# Altium schematic sheet 419-005-01-02_PG 13 - Video_Out.SchDoc("419-005-01-02_PG 13 - Video_Out")
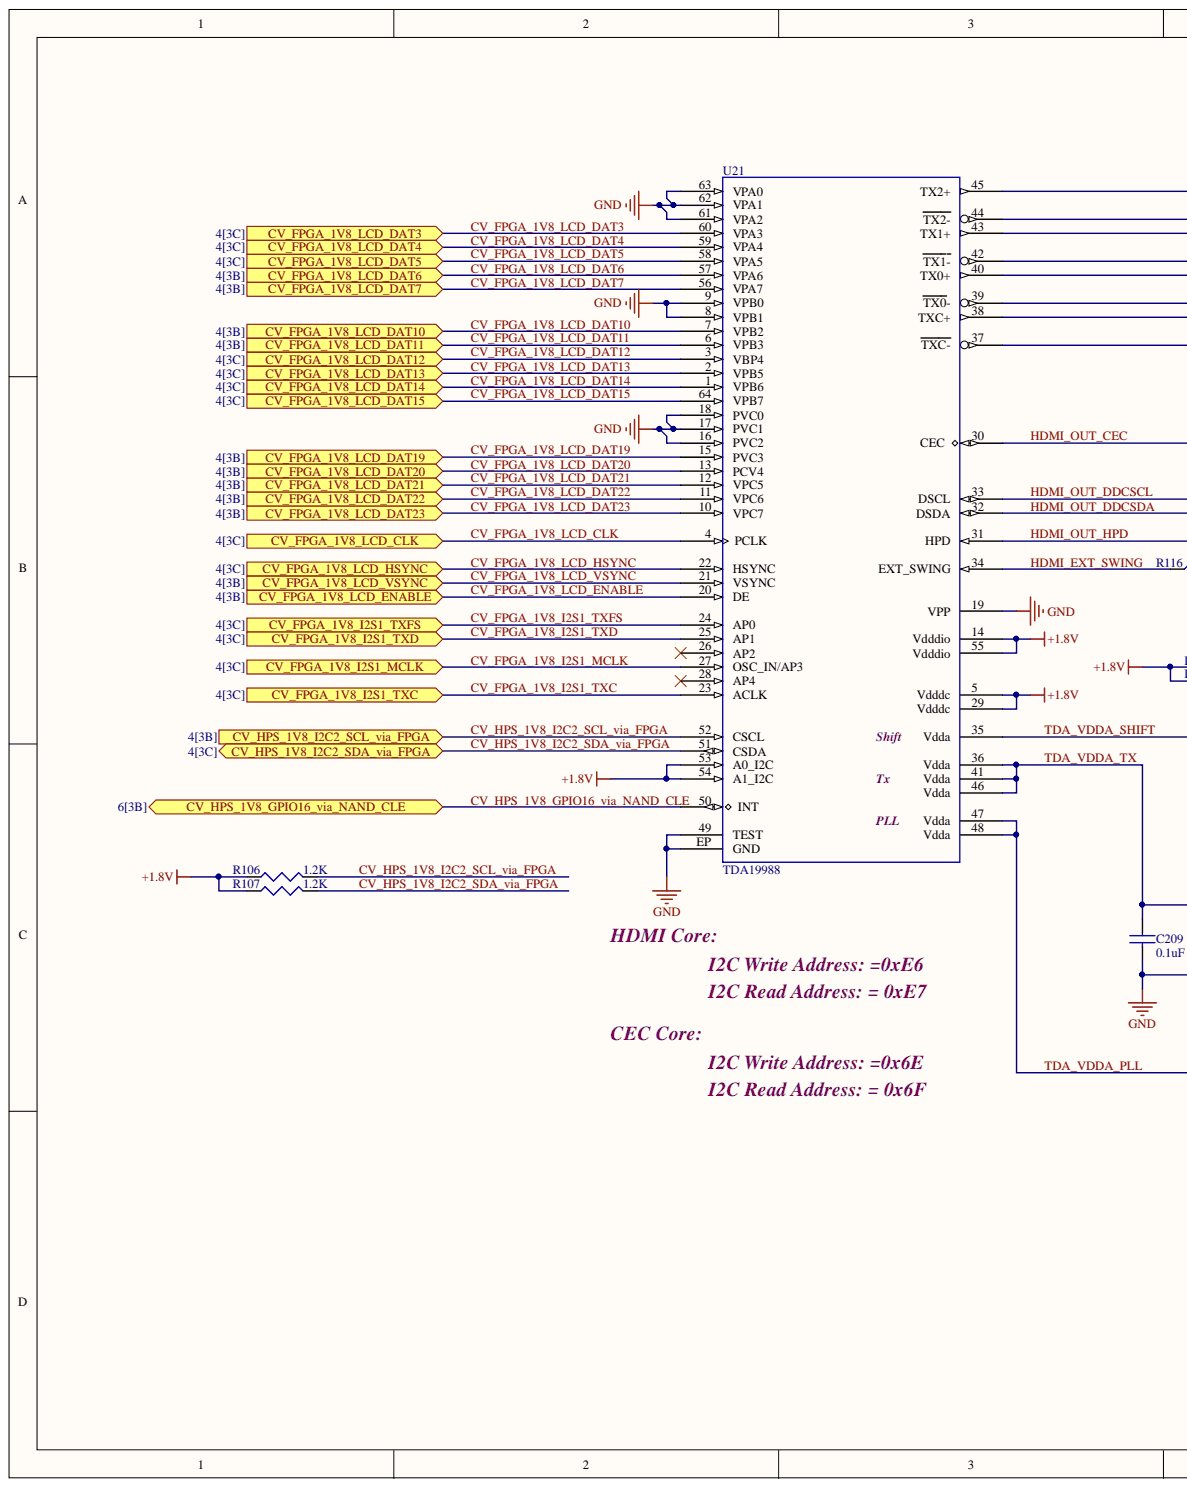
[diagram p0: 419-005-01-02_PG 13 - Video_Out.SchDoc, left]
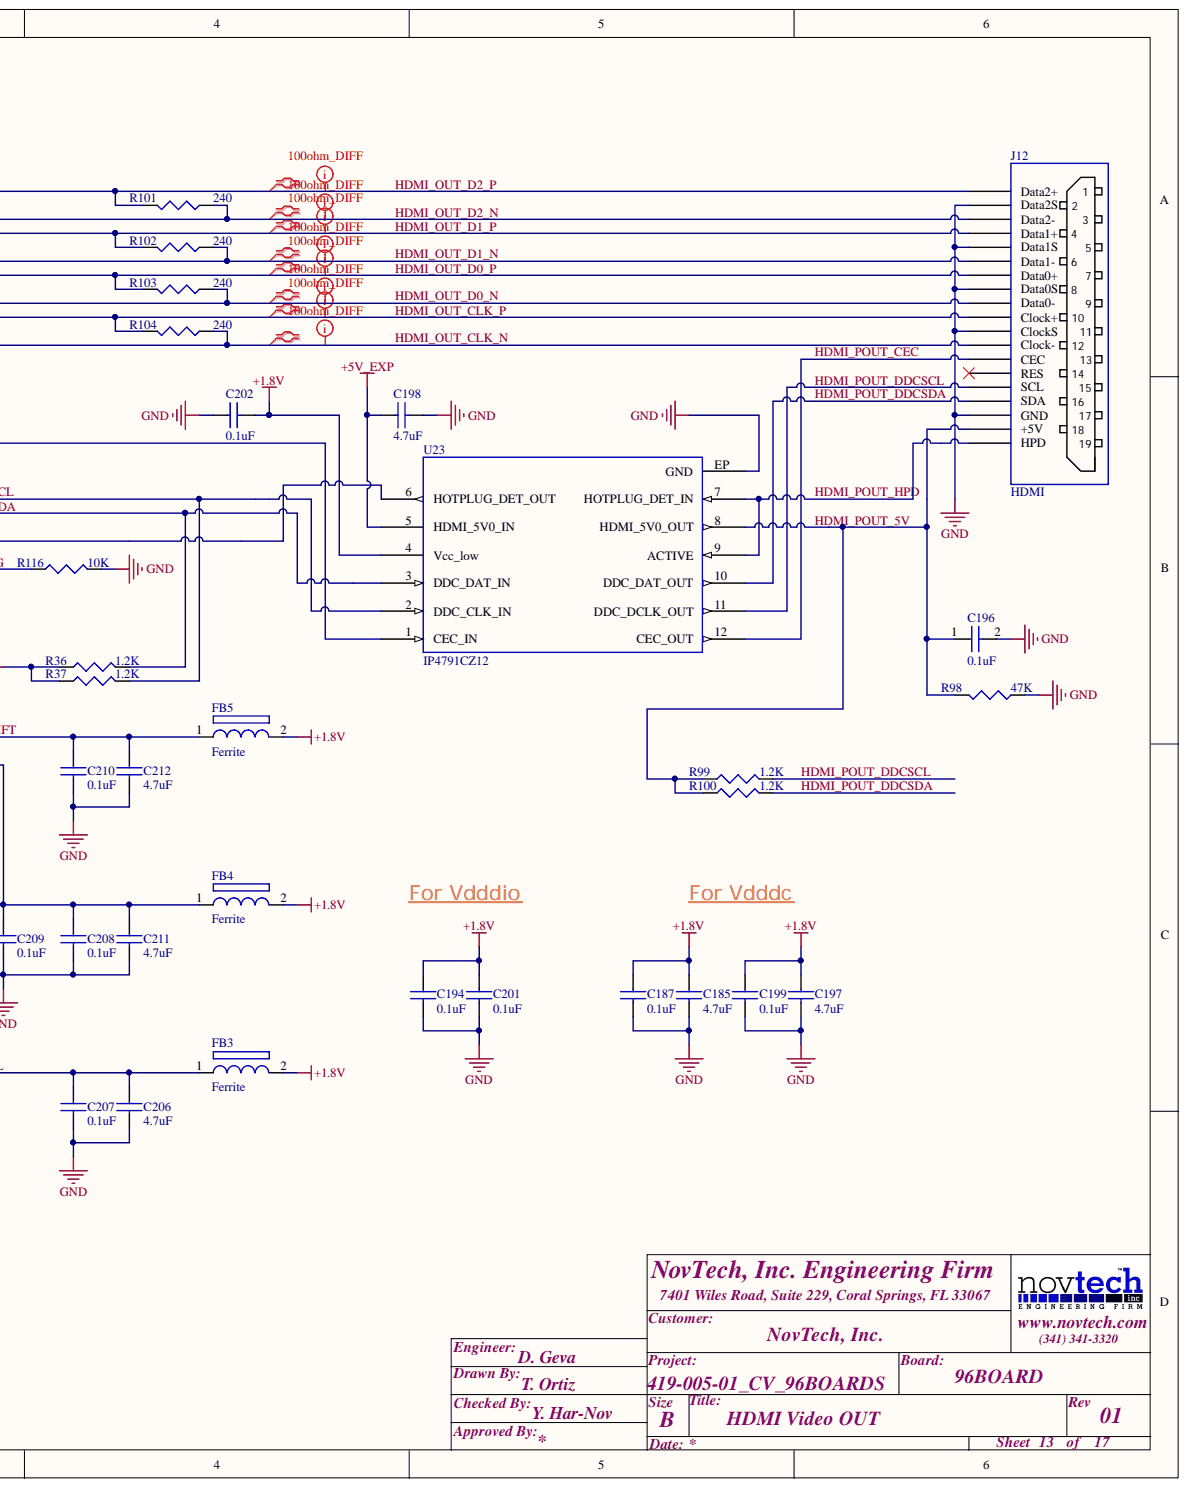
[diagram p1: 419-005-01-02_PG 13 - Video_Out.SchDoc, right]

## Parts
C185  4.7uF  [0402_C]
C187  0.1uF  [0201_C]
C194  0.1uF  [0201_C]
C196  0.1uF  [0201_C]
C197  4.7uF  [0402_C]
C198  4.7uF  [0402_C]
C199  0.1uF  [0201_C]
C201  0.1uF  [0201_C]
C202  0.1uF  [0201_C]
C206  4.7uF  [0402_C]
C207  0.1uF  [0201_C]
C208  0.1uF  [0201_C]
C209  0.1uF  [0201_C]
C210  0.1uF  [0201_C]
C211  4.7uF  [0402_C]
C212  4.7uF  [0402_C]
FB3  Ferrite  [0402_R]
FB4  Ferrite  [0402_R]
FB5  Ferrite  [0402_R]
J12  HDMI  [HDMI 10029449-X01]
R36  1.2K  [0201_R]
R37  1.2K  [0201_R]
R98  47K  [0402_R]
R99  1.2K  [0201_R]
R100  1.2K  [0201_R]
R101  240  [0201_R]
R102  240  [0201_R]
R103  240  [0201_R]
R104  240  [0201_R]
R106  1.2K  [0201_R]
R107  1.2K  [0201_R]
R116  10K  [0201_R]
U21  TDA19988  [QFN64_50P900X900X100-64N - 5.1MM PAD]
U23  IP4791CZ12  [HXSON12]

## Ports
CV_FPGA_1V8_I2S1_MCLK, CV_FPGA_1V8_I2S1_TXC, CV_FPGA_1V8_I2S1_TXD, CV_FPGA_1V8_I2S1_TXFS, CV_FPGA_1V8_LCD_CLK, CV_FPGA_1V8_LCD_DAT3, CV_FPGA_1V8_LCD_DAT4, CV_FPGA_1V8_LCD_DAT5, CV_FPGA_1V8_LCD_DAT6, CV_FPGA_1V8_LCD_DAT7, CV_FPGA_1V8_LCD_DAT10, CV_FPGA_1V8_LCD_DAT11, CV_FPGA_1V8_LCD_DAT12, CV_FPGA_1V8_LCD_DAT13, CV_FPGA_1V8_LCD_DAT14, CV_FPGA_1V8_LCD_DAT15, CV_FPGA_1V8_LCD_DAT19, CV_FPGA_1V8_LCD_DAT20, CV_FPGA_1V8_LCD_DAT21, CV_FPGA_1V8_LCD_DAT22, CV_FPGA_1V8_LCD_DAT23, CV_FPGA_1V8_LCD_ENABLE, CV_FPGA_1V8_LCD_HSYNC, CV_FPGA_1V8_LCD_VSYNC, CV_HPS_1V8_GPIO16_VIA_NAND_CLE, CV_HPS_1V8_I2C2_SCL_VIA_FPGA, CV_HPS_1V8_I2C2_SDA_VIA_FPGA

## Nets
+1.8V: C185.1 C187.1 C194.1 C197.1 C199.1 C201.1 C202.2 FB3.2 FB4.2 FB5.2 R36.1 R37.1 R106.1 R107.1 U21.5(Vdddc) U21.14(Vdddio) U21.29(Vdddc) U21.53(A0_I2C) U21.54(A1_I2C) U21.55(Vdddio) U23.4(Vcc_low)
+5V_EXP: C198.1 U23.5(HDMI_5V0_IN)
GND: C185.2 C187.2 C194.2 C196.2 C197.2 C198.2 C199.2 C201.2 C202.1 C206.2 C207.2 C208.2 C209.2 C210.2 C211.2 C212.2 J12.2(Data2S) J12.5(Data1S) J12.8(Data0S) J12.11(ClockS) J12.17(GND) R98.1 R116.2 U21.8(VPB1) U21.9(VPB0) U21.16(PVC2) U21.17(PVC1) U21.18(PVC0) U21.19(VPP) U21.49(TEST) U21.61(VPA2) U21.62(VPA1) U21.63(VPA0) U21.EP(GND) U23.EP(GND)
CV_FPGA_1V8_I2S1_MCLK: U21.27(OSC_IN/AP3) ; port CV_FPGA_1V8_I2S1_MCLK
CV_FPGA_1V8_I2S1_TXC: U21.23(ACLK) ; port CV_FPGA_1V8_I2S1_TXC
CV_FPGA_1V8_I2S1_TXD: U21.25(AP1) ; port CV_FPGA_1V8_I2S1_TXD
CV_FPGA_1V8_I2S1_TXFS: U21.24(AP0) ; port CV_FPGA_1V8_I2S1_TXFS
CV_FPGA_1V8_LCD_CLK: U21.4(PCLK) ; port CV_FPGA_1V8_LCD_CLK
CV_FPGA_1V8_LCD_DAT3: U21.60(VPA3) ; port CV_FPGA_1V8_LCD_DAT3
CV_FPGA_1V8_LCD_DAT4: U21.59(VPA4) ; port CV_FPGA_1V8_LCD_DAT4
CV_FPGA_1V8_LCD_DAT5: U21.58(VPA5) ; port CV_FPGA_1V8_LCD_DAT5
CV_FPGA_1V8_LCD_DAT6: U21.57(VPA6) ; port CV_FPGA_1V8_LCD_DAT6
CV_FPGA_1V8_LCD_DAT7: U21.56(VPA7) ; port CV_FPGA_1V8_LCD_DAT7
CV_FPGA_1V8_LCD_DAT10: U21.7(VPB2) ; port CV_FPGA_1V8_LCD_DAT10
CV_FPGA_1V8_LCD_DAT11: U21.6(VPB3) ; port CV_FPGA_1V8_LCD_DAT11
CV_FPGA_1V8_LCD_DAT12: U21.3(VBP4) ; port CV_FPGA_1V8_LCD_DAT12
CV_FPGA_1V8_LCD_DAT13: U21.2(VPB5) ; port CV_FPGA_1V8_LCD_DAT13
CV_FPGA_1V8_LCD_DAT14: U21.1(VPB6) ; port CV_FPGA_1V8_LCD_DAT14
CV_FPGA_1V8_LCD_DAT15: U21.64(VPB7) ; port CV_FPGA_1V8_LCD_DAT15
CV_FPGA_1V8_LCD_DAT19: U21.15(PVC3) ; port CV_FPGA_1V8_LCD_DAT19
CV_FPGA_1V8_LCD_DAT20: U21.13(PCV4) ; port CV_FPGA_1V8_LCD_DAT20
CV_FPGA_1V8_LCD_DAT21: U21.12(VPC5) ; port CV_FPGA_1V8_LCD_DAT21
CV_FPGA_1V8_LCD_DAT22: U21.11(VPC6) ; port CV_FPGA_1V8_LCD_DAT22
CV_FPGA_1V8_LCD_DAT23: U21.10(VPC7) ; port CV_FPGA_1V8_LCD_DAT23
CV_FPGA_1V8_LCD_ENABLE: U21.20(DE) ; port CV_FPGA_1V8_LCD_ENABLE
CV_FPGA_1V8_LCD_HSYNC: U21.22(HSYNC) ; port CV_FPGA_1V8_LCD_HSYNC
CV_FPGA_1V8_LCD_VSYNC: U21.21(VSYNC) ; port CV_FPGA_1V8_LCD_VSYNC
CV_HPS_1V8_GPIO16_VIA_NAND_CLE: U21.50(INT) ; port CV_HPS_1V8_GPIO16_VIA_NAND_CLE
CV_HPS_1V8_I2C2_SCL_VIA_FPGA: R106.2 U21.52(CSCL) ; port CV_HPS_1V8_I2C2_SCL_VIA_FPGA
CV_HPS_1V8_I2C2_SDA_VIA_FPGA: R107.2 U21.51(CSDA) ; port CV_HPS_1V8_I2C2_SDA_VIA_FPGA
HDMI_EXT_SWING: R116.1 U21.34(EXT_SWING)
HDMI_OUT_CEC: U21.30(CEC) U23.1(CEC_IN)
HDMI_OUT_CLK_N: J12.12(Clock-) R104.1 U21.37(TXC-)
HDMI_OUT_CLK_P: J12.10(Clock+) R104.2 U21.38(TXC+)
HDMI_OUT_D0_N: J12.9(Data0-) R103.1 U21.39(TX0-)
HDMI_OUT_D0_P: J12.7(Data0+) R103.2 U21.40(TX0+)
HDMI_OUT_D1_N: J12.6(Data1-) R102.1 U21.42(TX1-)
HDMI_OUT_D1_P: J12.4(Data1+) R102.2 U21.43(TX1+)
HDMI_OUT_D2_N: J12.3(Data2-) R101.1 U21.44(TX2-)
HDMI_OUT_D2_P: J12.1(Data2+) R101.2 U21.45(TX2+)
HDMI_OUT_DDCSCL: R37.2 U21.33(DSCL) U23.2(DDC_CLK_IN)
HDMI_OUT_DDCSDA: R36.2 U21.32(DSDA) U23.3(DDC_DAT_IN)
HDMI_OUT_HPD: U21.31(HPD) U23.6(HOTPLUG_DET_OUT)
HDMI_POUT_5V: C196.1 J12.18(+5V) R98.2 R99.1 R100.1 U23.8(HDMI_5V0_OUT)
HDMI_POUT_CEC: J12.13(CEC) U23.12(CEC_OUT)
HDMI_POUT_DDCSCL: J12.15(SCL) R99.2 U23.11(DDC_DCLK_OUT)
HDMI_POUT_DDCSDA: J12.16(SDA) R100.2 U23.10(DDC_DAT_OUT)
HDMI_POUT_HPD: J12.19(HPD) U23.7(HOTPLUG_DET_IN) U23.9(ACTIVE)
TDA_VDDA_PLL: C206.1 C207.1 FB3.1 U21.47(Vdda) U21.48(Vdda)
TDA_VDDA_SHIFT: C210.1 C212.1 FB5.1 U21.35(Vdda)
TDA_VDDA_TX: C208.1 C209.1 C211.1 FB4.1 U21.36(Vdda) U21.41(Vdda) U21.46(Vdda)

Not connected: J12 14(RES); U21 26(AP2) 28(AP4)

## Library data of the parts
C185 C197 C198 C206 C211 C212 = CAP_0402: Capasitor 0402 footprint; Bottom: 10; Right: 20; Component Kind: Standard; Library Name: PASSIVES.SchLib
C187 C194 C196 C199 C201 C202 C207-C210 = CAP_0201: Capasitor 0201 footprint; Component Kind: Standard; Library Name: PASSIVES.SchLib
FB3-FB5 = INDUCTOR_BEAD: TEMP: -55°C to +85°C; TYPE: SMT; Component Kind: Standard; Library Name: PASSIVES.SchLib; RoHS: Yes
J12 = HDMI: PART: 10029449-001RLF; MFG: FCI; Component Kind: Standard; DigiKey: 609-1010-1-ND; Library Name: CONNECTORS_MECHANICAL.SchLib; RoHS: Yes
R36 R37 R99 R100 R106 R107 = RESISOTR 0201: Resistor 0201 footprint; PART: ERJ-1GEF1201C; MFG: Panasonic; Component Kind: Standard; Digikey: P1.20KABCT-ND; Library Name: PASSIVES.SchLib; RoHS: Yes; TYPE: SMT
R98 = RESISOTR 0402: Resistor 0402 footprint; Component Kind: Standard; Library Name: PASSIVES.SchLib
R101-R104 = RESISOTR 0201: Resistor 0201 footprint; PART: ERJ-1GEF2400C; MFG: Panasonic; Component Kind: Standard; Digikey: P240ABTR-ND; Library Name: PASSIVES.SchLib; RoHS: Yes; TYPE: SMT
R116 = RESISOTR 0201: Resistor 0201 footprint; PART: ERJ-1GEF1002C; MFG: Panasonic; Component Kind: Standard; Digikey: P10.0KABCT-ND; Library Name: PASSIVES.SchLib; RoHS: Yes; TYPE: SMT
U21 = TDA19988: HDMI 1.4 transmitter with HDCP and CEC support; PART: TDA19988BHN/C1,557; MFG: NXP; TEMP: -20°C to +85°C; Component Kind: Standard; DigiKey: 568-12059-ND; Library Name: IC_SchLib.SCHLIB; RoHS: Yes; TYPE: SMT
U23 = IP4791CZ12: HDMI ESD protection, level shifter and backdrive protection; PART: IP4791CZ12,132; MFG: NXP; TEMP: -40°C to +85°C; Component Kind: Standard; Digikey: 568-7212-1-ND; Library Name: IC_SchLib.SCHLIB; RoHS: Yes; TYPE: SMT
